# Altium schematic sheet Smart_Lock_Schematic.SchDoc
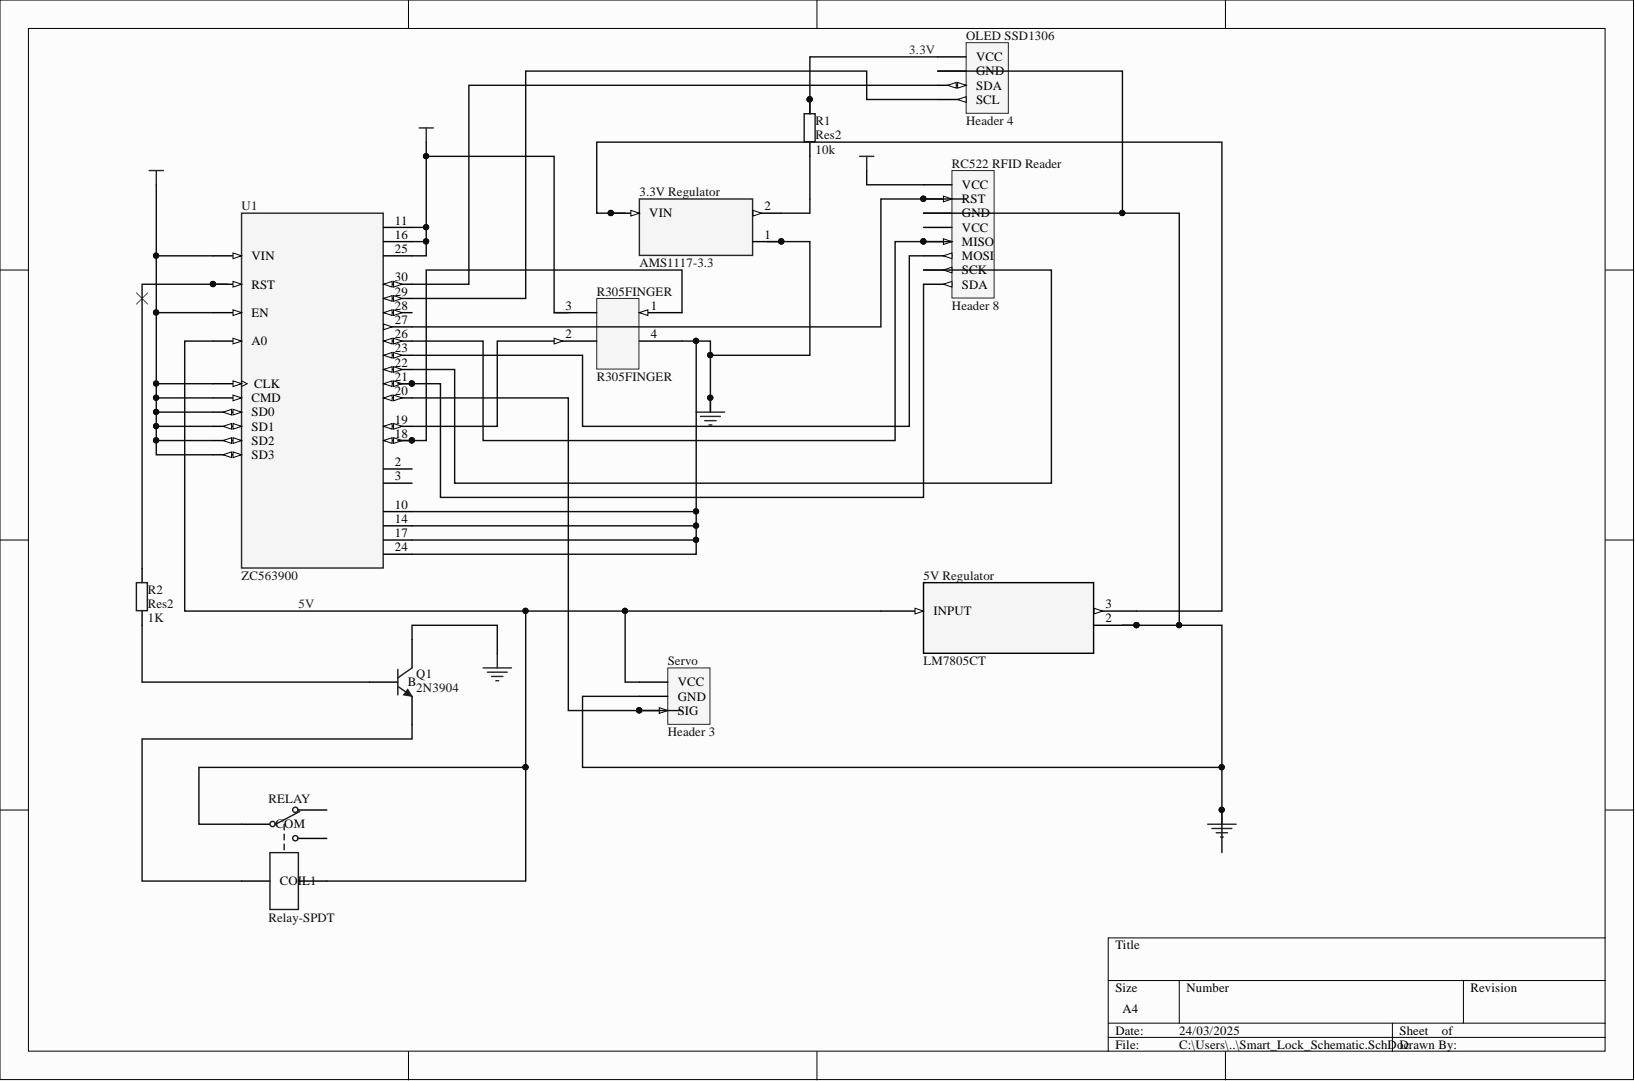
[diagram p0: Smart_Lock_Schematic.SchDoc]

## Parts
3.3V_Regulator  AMS1117-3.3  [SOT229P700X180-4N]
5V_Regulator  LM7805CT  [TO220-3_ONS]
OLED_SSD1306  Header 4  [HDR1X4]
Q1  2N3904  [TO-92A]
R1  Res2  value 10k  [AXIAL-0.4]
R2  Res2  value 1K  [AXIAL-0.4]
R305FINGER  R305FINGER
RC522_RFID_Reader  Header 8  [HDR1X8]
RELAY  Relay-SPDT  [MODULE5B]
Servo  Header 3  [HDR1X3]
U1  ZC563900  [MODULE_ZC563900]

## Nets
GND: 3.3V_Regulator.1 5V_Regulator.2 OLED_SSD1306.2(GND) Q1.3 R305FINGER.4 RC522_RFID_Reader.3(GND) Servo.2 U1.10 U1.14 U1.17 U1.24
VCC: RC522_RFID_Reader.1(VCC) U1.4(SD3) U1.5(SD2) U1.6(SD1) U1.7(CMD) U1.8(SD0) U1.9(CLK) U1.12(EN) U1.15(VIN)
3.3V: OLED_SSD1306.1(VCC) R1.2 U1.11 U1.16 U1.25
5V: 5V_Regulator.1 RELAY.1(COM) RELAY.5 Servo.1 U1.1(A0)
Net3.3V Regulator_2: 3.3V_Regulator.2 R1.1
Net3.3V Regulator_3: 3.3V_Regulator.3 5V_Regulator.3
NetOLED SSD1306_3: OLED_SSD1306.3(SDA) U1.30
NetOLED SSD1306_4: OLED_SSD1306.4(SCL) U1.29
NetQ1_1: Q1.1 RELAY.4(COIL1)
NetQ1_2: Q1.2 R2.1
NetR2_2: R2.2 U1.13(RST)
NetR305FINGER_1: R305FINGER.1 U1.18
NetRC522 RFID Reader_2: RC522_RFID_Reader.2(RST) U1.27
NetRC522 RFID Reader_5: RC522_RFID_Reader.5(MISO) U1.26
NetRC522 RFID Reader_6: RC522_RFID_Reader.6(MOSI) U1.23
NetRC522 RFID Reader_7: RC522_RFID_Reader.7(SCK) U1.22
NetRC522 RFID Reader_8: RC522_RFID_Reader.8(SDA) U1.21
NetServo_3: Servo.3 U1.20

Not connected: R305FINGER 2 3; RC522_RFID_Reader 4(VCC); RELAY 2 3; U1 2 3 19 28

## Library data of the parts
3.3V_Regulator = AMS1117-3.3: Sot223/Pkg 1-Amp 3.3-Volt Low Drop Out Voltage Regulatator; MF: Advanced Monolithic Systems; Check_prices: https://www.snapeda.com/parts/AMS1117-3.3/Advanced+Monolithic+Systems/view-part/?ref=eda; Component Kind: Standard; Library Name: AMS1117-3.3.IntLib; MP: AMS1117-3.3; Package: SOT-223 Seeed Technology; SnapEDA_Link: https://www.snapeda.com/parts/AMS1117-3.3/Advanced+Monolithic+Systems/view-part/?ref=snap
5V_Regulator = LM7805CT: No Description Available; Mfr_Name: onsemi; Component Kind: Standard; Library Name: LM7805CT.SchLib; RefDes: RefDes; Type: Type
OLED_SSD1306 = Header 4: Header, 4-Pin; Component Kind: Standard; LatestRevisionDate: 17-Jul-2002; LatestRevisionNote: Re-released for DXP Platform.; Library Name: Miscellaneous Connectors.IntLib; Signal Integrity: Connector; Publisher: Altium Limited
Q1 = 2N3904: NPN General Purpose Amplifier; Component Kind: Standard; ComponentLink1Description: Manufacturer Link; ComponentLink1URL: http://www.fairchildsemi.com/; ComponentLink2Description: Datasheet; ComponentLink2URL: http://www.fairchildsemi.com/ds/2N%2F2N3904.pdf; DatasheetVersion: 1997; LatestRevisionDate: 15-Jan-2003; LatestRevisionNote: PCB Footprint 'BCY-W3/D4.7' replaced; Library Name: Miscellaneous Devices.IntLib; PackageDescription: TO, Flat Index; 3 In-Line, Axial Leads; Body Dia. 4.5mm; Leads 0.51 x 0.48 mm (max); PackageReference: TO-92A; PackageVersion: Sep-1998; Published: 8-Jun-2000; Signal Integrity: 2N3904; Simulation: 2N3904; Publisher: Altium Limited
R1 R2 = Res2: Resistor; Component Kind: Standard; LatestRevisionDate: 17-Jul-2002; LatestRevisionNote: Re-released for DXP Platform.; Library Name: Miscellaneous Devices.IntLib; PackageDescription: Axial Device, Thru-Hole; 2 Leads; 0.4 in Pin Spacing; PackageReference: AXIAL-0.4; Published: 8-Jun-2000; Signal Integrity: Res; Simulation: RESISTOR; Publisher: Altium Limited
RC522_RFID_Reader = Header 8: Header, 8-Pin; Component Kind: Standard; LatestRevisionDate: 17-Jul-2002; LatestRevisionNote: Re-released for DXP Platform.; Library Name: Miscellaneous Connectors.IntLib; Signal Integrity: Connector; Publisher: Altium Limited
RELAY = Relay-SPDT: Single-Pole Dual-Throw Relay; Component Kind: Standard; LatestRevisionDate: 17-Jul-2002; LatestRevisionNote: Re-released for DXP Platform.; Library Name: Miscellaneous Devices.IntLib; PackageReference: MODULE5B; Published: 24-Mar-1999; Simulation: SPDTRELAY; Publisher: Altium Limited
Servo = Header 3: Header, 3-Pin; Component Kind: Standard; LatestRevisionDate: 17-Jul-2002; LatestRevisionNote: Re-released for DXP Platform.; Library Name: Miscellaneous Connectors.IntLib; Signal Integrity: Connector; Publisher: Altium Limited
U1 = ZC563900: NodeMcu Lua ESP8266 WIFI Internet of Things Network Development Module Board; MF: YKS; Availability: Not in stock; Check_prices: https://www.snapeda.com/parts/ZC563900/YKS/view-part/?ref=eda; Component Kind: Standard; Library Name: ZC563900.IntLib; MP: ZC563900; Package: None; Price: None; SnapEDA_Link: https://www.snapeda.com/parts/ZC563900/YKS/view-part/?ref=snap
R305FINGER = R305FINGER: Component Kind: Standard; Library Name: R305FS.SchLib
